# Altium schematic sheet 1-Controller.SchDoc(1-Controller)
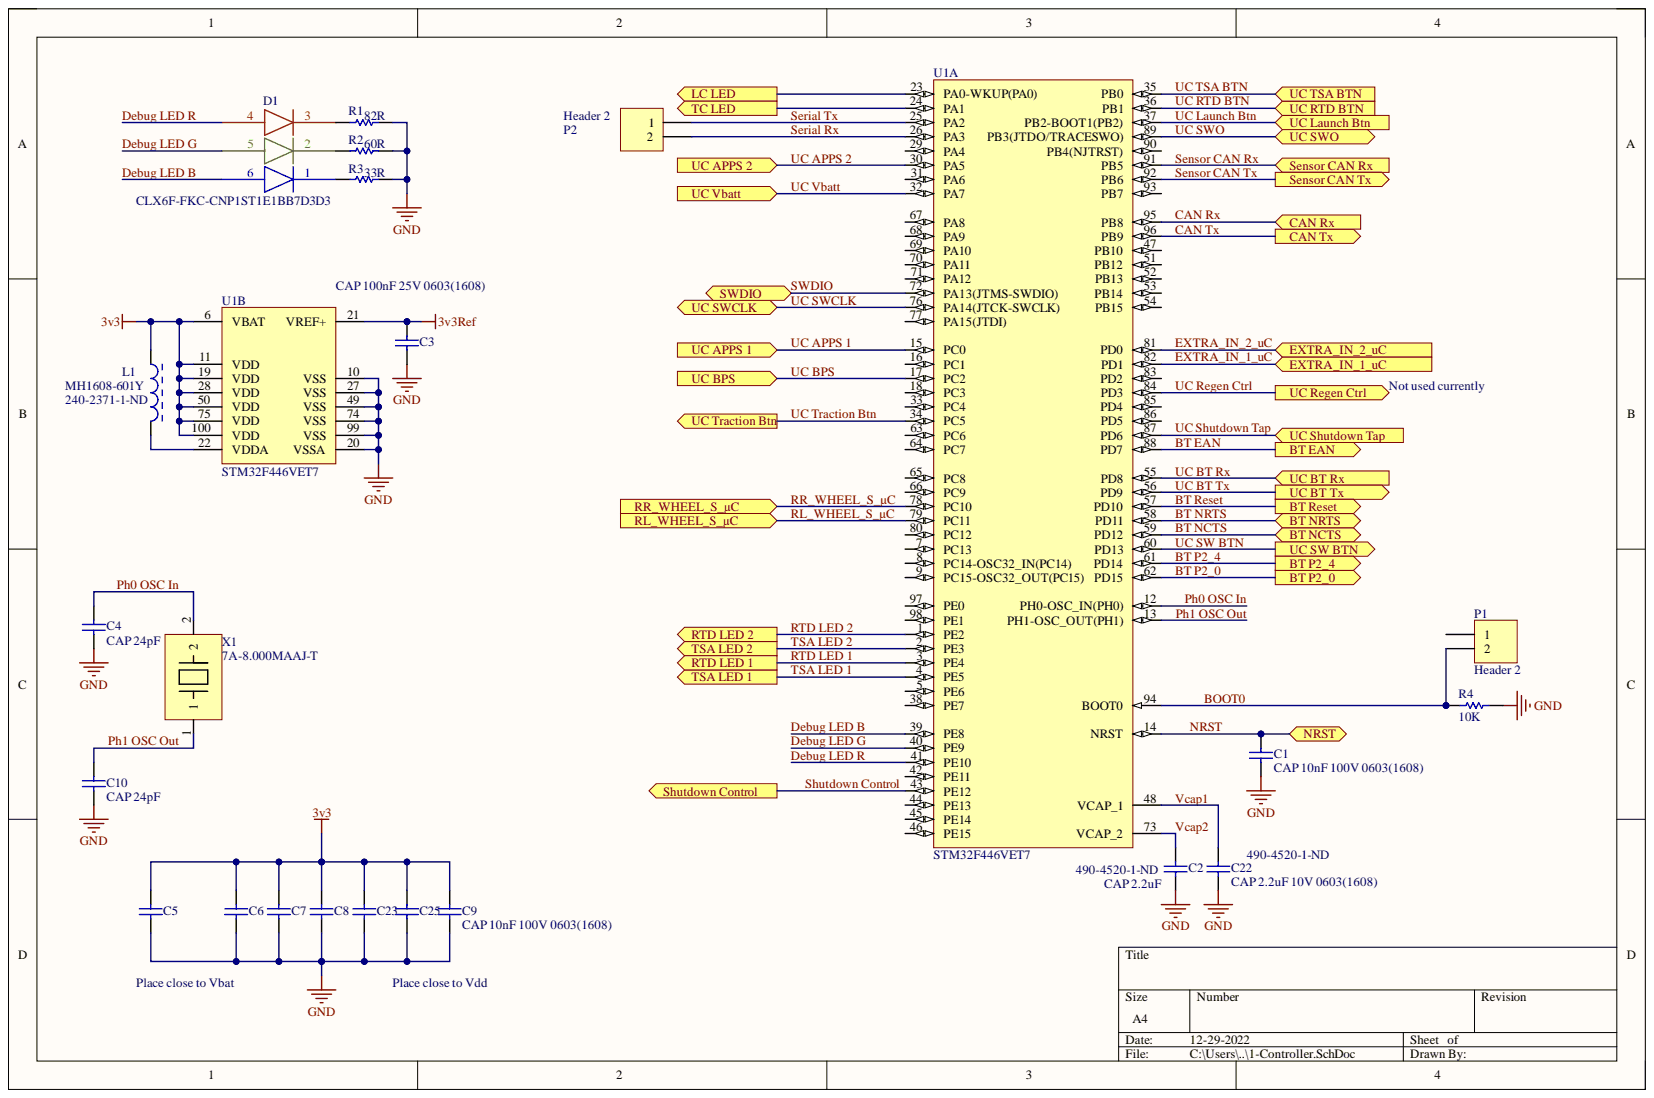
[diagram p0: 1-Controller.SchDoc]

## Parts
C1  CAP 10nF 100V 0603(1608)  value 10nF  [CAPC0603(1608)100_M]
C2  CAP 2.2uF  value 2.2uF  Supplier Part Number 1: 490-4520-1-ND  [CAPC0603(1608)55_N]
C3  CAP 100nF 25V 0603(1608)  value 100nF  [CAPC0603(1608)100_N]
C4  CAP 24pF  value 24pF  [CAPC0603(1608)100_N]
C5  CAP 10nF 100V 0603(1608)  [CAPC0603(1608)100_M]
C6  CAP 10nF 100V 0603(1608)  [CAPC0603(1608)100_M]
C7  CAP 10nF 100V 0603(1608)  [CAPC0603(1608)100_M]
C8  CAP 10nF 100V 0603(1608)  [CAPC0603(1608)100_M]
C9  CAP 10nF 100V 0603(1608)  value 10nF  [CAPC0603(1608)100_M]
C10  CAP 24pF  value 24pF  [CAPC0603(1608)100_N]
C22  CAP 2.2uF 10V 0603(1608)  value 2.2uF  Supplier Part Number 1: 490-4520-1-ND  [CAPC0603(1608)55_N]
C23  CAP 10nF 100V 0603(1608)  [CAPC0603(1608)100_M]
C25  CAP 10nF 100V 0603(1608)  [CAPC0603(1608)100_M]
D1  CLX6F-FKC-CNP1ST1E1BB7D3D3  [CLX6C-FKB]
L1  MH1608-601Y  Supplier Part Number 1: 240-2371-1-ND  [BOUR-MH1608_V]
P1  Header 2  [HDR1X2]
P2  Header 2  [HDR1X2]
R1  82R  [RESC0603(1608)_N]
R2  60R  [RESC0603(1608)_N]
R3  33R  [RESC0603(1608)_N]
R4  10K  [RESC0603(1608)_N]
U1  STM32F446VET7  [STM-LQFP100_L]  (parts A,B)
X1  7A-8.000MAAJ-T  [2-SMD, No Lead]

## Ports
BT EAN, BT NCTS, BT NRTS, BT P2_0, BT P2_4, BT Reset, CAN Rx, CAN Tx, EXTRA_IN_1_uC, EXTRA_IN_2_uC, LC LED, NRST, RL_WHEEL_S_µC, RR_WHEEL_S_µC, RTD LED 1, RTD LED 2, Sensor CAN Rx, Sensor CAN Tx, Shutdown Control, SWDIO, TC LED, TSA LED 1, TSA LED 2, UC APPS 1, UC APPS 2, UC BPS, UC BT Rx, UC BT Tx, UC Launch Btn, UC Regen Ctrl, UC RTD BTN, UC Shutdown Tap, UC SW BTN, UC SWCLK, UC SWO, UC Traction Btn, UC TSA BTN, UC Vbatt

## Nets
3v3: C5.2 C6.2 C7.2 C8.2 C9.2 C23.2 C25.2 L1.1 U1.6(VBAT) U1.11(VDD) U1.19(VDD) U1.28(VDD) U1.50(VDD) U1.75(VDD) U1.100(VDD)
3v3Ref: C3.2 U1.21(VREF+)
GND: C1.1 C2.1 C3.1 C4.1 C5.1 C6.1 C7.1 C8.1 C9.1 C10.1 C22.1 C23.1 C25.1 R1.2 R2.2 R3.2 R4.2 U1.10(VSS) U1.20(VSSA) U1.27(VSS) U1.49(VSS) U1.74(VSS) U1.99(VSS)
BOOT0: P1.2 R4.1 U1.94(BOOT0)
BT EAN: U1.88(PD7) ; port BT EAN
BT NCTS: U1.59(PD12) ; port BT NCTS
BT NRTS: U1.58(PD11) ; port BT NRTS
BT P2_0: U1.62(PD15) ; port BT P2_0
BT P2_4: U1.61(PD14) ; port BT P2_4
BT Reset: U1.57(PD10) ; port BT Reset
CAN Rx: U1.95(PB8) ; port CAN Rx
CAN Tx: U1.96(PB9) ; port CAN Tx
Debug LED B: D1.6 U1.39(PE8)
Debug LED G: D1.5 U1.40(PE9)
Debug LED R: D1.4 U1.41(PE10)
EXTRA_IN_1_uC: U1.82(PD1) ; port EXTRA_IN_1_uC
EXTRA_IN_2_uC: U1.81(PD0) ; port EXTRA_IN_2_uC
LC LED: U1.23(PA0-WKUP(PA0)) ; port LC LED
NRST: C1.2 U1.14(NRST) ; port NRST
Ph0 OSC In: C4.2 U1.12(PH0-OSC_IN(PH0)) X1.2
Ph1 OSC Out: C10.2 U1.13(PH1-OSC_OUT(PH1)) X1.1
RL_WHEEL_S_µC: U1.79(PC11) ; port RL_WHEEL_S_µC
RR_WHEEL_S_µC: U1.78(PC10) ; port RR_WHEEL_S_µC
RTD LED 1: U1.3(PE4) ; port RTD LED 1
RTD LED 2: U1.1(PE2) ; port RTD LED 2
Sensor CAN Rx: U1.91(PB5) ; port Sensor CAN Rx
Sensor CAN Tx: U1.92(PB6) ; port Sensor CAN Tx
Serial Rx: P2.2 U1.26(PA3)
Serial Tx: P2.1 U1.25(PA2)
Shutdown Control: U1.43(PE12) ; port Shutdown Control
SWDIO: U1.72(PA13(JTMS-SWDIO)) ; port SWDIO
TC LED: U1.24(PA1) ; port TC LED
TSA LED 1: U1.4(PE5) ; port TSA LED 1
TSA LED 2: U1.2(PE3) ; port TSA LED 2
UC APPS 1: U1.15(PC0) ; port UC APPS 1
UC APPS 2: U1.30(PA5) ; port UC APPS 2
UC BPS: U1.17(PC2) ; port UC BPS
UC BT Rx: U1.55(PD8) ; port UC BT Rx
UC BT Tx: U1.56(PD9) ; port UC BT Tx
UC Launch Btn: U1.37(PB2-BOOT1(PB2)) ; port UC Launch Btn
UC Regen Ctrl: U1.84(PD3) ; port UC Regen Ctrl
UC RTD BTN: U1.36(PB1) ; port UC RTD BTN
UC Shutdown Tap: U1.87(PD6) ; port UC Shutdown Tap
UC SW BTN: U1.60(PD13) ; port UC SW BTN
UC SWCLK: U1.76(PA14(JTCK-SWCLK)) ; port UC SWCLK
UC SWO: U1.89(PB3(JTDO/TRACESWO)) ; port UC SWO
UC Traction Btn: U1.34(PC5) ; port UC Traction Btn
UC TSA BTN: U1.35(PB0) ; port UC TSA BTN
UC Vbatt: U1.32(PA7) ; port UC Vbatt
Vcap1: C22.2 U1.48(VCAP_1)
Vcap2: C2.2 U1.73(VCAP_2)
NetD1_1: D1.1 R3.1
NetD1_2: D1.2 R2.1
NetD1_3: D1.3 R1.1
NetL1_2: L1.2 U1.22(VDDA)

Not connected: P1 1; U1 5(PE6) 7(PC13) 8(PC14-OSC32_IN(PC14)) 9(PC15-OSC32_OUT(PC15)) 16(PC1) 18(PC3) 29(PA4) 31(PA6) 33(PC4) 38(PE7) 42(PE11) 44(PE13) 45(PE14) 46(PE15) 47(PB10) 51(PB12) 52(PB13) 53(PB14) 54(PB15) 63(PC6) 64(PC7) 65(PC8) 66(PC9) 67(PA8) 68(PA9) 69(PA10) 70(PA11) 71(PA12) 77(PA15(JTDI)) 80(PC12) 83(PD2) 85(PD4) 86(PD5) 90(PB4(NJTRST)) 93(PB7) 97(PE0) 98(PE1)

## Library data of the parts
C1 C5-C9 C23 C25 = CMP-1035-02326-1: CAP 10nF 100V ±20% 0603 (1608 Metric) Thickness 1mm SMD; Case-EIA: 0603; Case-Metric: 1608; Tolerance: Â±20%; Component Kind: Standard; Library Name: Altium Content Vault; Max Thickness: 1 mm; Rated Voltage: 100 V; Technology: SMT
  C5-C8 C23 C25: Value: 10nF
C2 C22 = CMP-1035-02757-1: CAP 2.2uF 10V ±10% 0603 (1608 Metric) Thickness 0.55mm SMD; Case-EIA: 0603; Case-Metric: 1608; Tolerance: Â±10%; Component Kind: Standard; Library Name: Altium Content Vault; Max Thickness: 0.55 mm; Rated Voltage: 10 V; Supplier 1: Digi-Key; Technology: SMT
C3 = CMP-1035-02589-1: CAP 100nF 25V ±20% 0603 (1608 Metric) Thickness 1mm SMD; Case-EIA: 0603; Case-Metric: 1608; Tolerance: Â±20%; Component Kind: Standard; Library Name: Altium Content Vault; Max Thickness: 1 mm; Rated Voltage: 25 V; Technology: SMT; Value: 100nF
C4 C10 = CMP-1035-00843-1: CAP 24pF 16V ±2% 0603 (1608 Metric) Thickness 1mm SMD; Case-EIA: 0603; Case-Metric: 1608; Tolerance: Â±2%; Component Kind: Standard; Library Name: Altium Content Vault; Max Thickness: 1 mm; Rated Voltage: 16 V; Technology: SMT
  C4: Value: 24pF
D1 = LED - CLX6F-FKC-CNP1ST1E1BB7D3D3: Red, Green, Blue (RGB) 622nm Red, 530nm Green, 470nm Blue LED Indication - Discrete 2.1V Red, 2.7V Green, 3V Blue 6-SMD, J-Lead; Component Kind: Standard; ComponentLink1Description: Digikey; ComponentLink1URL: https://www.digikey.ca/product-detail/en/cree-inc/CLX6F-FKC-CNP1ST1E1BB7D3D3/CLX6F-FKC-CNP1ST1E1BB7D3D3CT-ND/6362814; Library Name: VCB_2019.SchLib
L1 = CMP-2000-06698-1: Chip Bead, 600 Ohm, 1 A, -55 to 125 degC, 2-Pin SMD (0603), RoHS, Tape and Reel; Manufacturer: Bourns; Current Rating (Max): 1A; DC Resistance (DCR) (Max): 200mOhm; EDA / CAD Models: DownloadfromAcceleratedDesigns; Filter Type: Power,SignalLine; Impedance @ Frequency: 600Ohm@100MHz; Number of Lines: 1; Online Catalog: MHSeries; Other Names: MH1608-601YCT; Category: Filters; Component Kind: Standard; Datasheet URL: http://www.bourns.com/docs/Product-Datasheets/mh.pdf; Datasheet Version: 03/2015; Family: FerriteBeadsandChips; Library Name: Altium Content Vault; Manufacturer URL: http://www.bourns.com/; Mounting Technology: SurfaceMount; Mounting Type: SurfaceMount; Operating Temperature: -55°C~125°C; Package / Case: 0603(1608Metric); Package Description: 2-Pin Surface Mount Device, Body 1.6 x 0.8 mm; Package Reference: 0603; Packaging: CutTape(CT); Ratings: -; RoHS Information: MH1608SeriesMaterialDeclaration; Series: MH; Standard Package: 1; Supplier 1: Digi-Key
P1 P2 = Header 2: Header, 2-Pin; Component Kind: Standard; LatestRevisionDate: 17-Jul-2002; LatestRevisionNote: Re-released for DXP Platform.; Library Name: Miscellaneous Connectors.IntLib; Publisher: Altium Limited
R1 = CMP-1012-00048-1: 82R 0.1W 5% 0603 (1608 Metric)  SMD; Case-EIA: 0603; Case-Metric: 1608; Value: 82.00 Ohm; Component Kind: Standard; Library Name: Altium Content Vault; Power: 0.1 W; Technology: SMT; Tolerance: 5 %
R2 = CMP-1012-00372-1: 60R4 0.1W 1% 0603 (1608 Metric)  SMD; Case-EIA: 0603; Case-Metric: 1608; Value: 60.40 Ohm; Component Kind: Standard; Library Name: Altium Content Vault; Power: 0.1 W; Technology: SMT; Tolerance: 1 %
R3 = CMP-1012-00038-1: 33R 0.1W 5% 0603 (1608 Metric)  SMD; Case-EIA: 0603; Case-Metric: 1608; Value: 33.00 Ohm; Component Kind: Standard; Library Name: Altium Content Vault; Power: 0.1 W; Technology: SMT; Tolerance: 5 %
R4 = CMP-1012-00098-1: 10K 0.1W 5% 0603 (1608 Metric)  SMD; Case-EIA: 0603; Case-Metric: 1608; Value: 10000.00 Ohm; Component Kind: Standard; Library Name: Altium Content Vault; Power: 0.1 W; Technology: SMT; Tolerance: 5 %
U1 = CMP-0243-00221-1: ARM Cortex-M4 32-bit MCU+FPU, 512 KB Flash, 128 KB Internal RAM, 81 I/Os, 100-Pin LQFP, -40 to 105 degC, Tray; D/A Converter: 2; FLASH Size (Prog) (kB): 512; I/Os (High Current): 81; Internal RAM Size (kB): 128; Operating Frequency(F) (Processor speed) (MHz): 180; Supply Voltage(Vcc) min (V): 1.7; Component Kind: Standard; ComponentLink1Description: Manufacturer URL; ComponentLink1URL: http://www.st.com/; ComponentLink2Description: Datasheet; ComponentLink2URL: http://www.st.com/st-web-ui/static/active/en/resource/technical/document/datasheet/DM00141306.pdf; Core: ARM Cortex-M4; DatasheetVersion: Rev. 6; Library Name: Altium Content Vault; Mounting Technology: Surface Mount; Package Description: LQFP100; Package Reference: 100-Pin Low Profile Quad Flat Package, Body 14 x 14 mm, Pitch 0.5 mm; Packing: Tray; PartId: 1
X1 = 8MHz crystal, 7A-8.000MAAJ-T: Manufacturer: TXC CORPORATION; ESR (Equivalent Series Resistance): 80 Ohm; Frequency: 8MHz; Frequency Stability: ±30ppm; Load Capacitance: 18pF; Operating Mode: Fundamental; Category: Crystals, Oscillators, Resonators; Component Kind: Standard; ComponentLink1Description: http://www.txccrystal.com/images/pdf/7a.pdf; ComponentLink1URL: http://www.txccrystal.com/images/pdf/7a.pdf; Frequency Tolerance: ±30ppm; Height - Seated (Max): 0.053" (1.35mm); Manufacturer Part Number: 7A-8.000MAAJ-T; Mounting Type: Surface Mount; Operating Temperature: -20°C ~ 70°C; Package / Case: 2-SMD, No Lead; Packaging: Cut Tape (CT); Part Status: Active; Pricing 1: 1=1.12, 10=0.989, 50=0.9234, 100=0.8162, 500=0.77322 (CAD); Ratings: -; RoHS: RoHS Compliant; Size / Dimension: 0.197" L x 0.126" W (5.00mm x 3.20mm); Stock 1: 30692; Supplier 1: Digi-Key; Supplier Part Number 1: 887-1448-1-ND; Type: MHz Crystal; Library Name: VCU Library.SchLib
